# Altium schematic sheet [06]_Connectivity.SchDoc([06]_Connectivity)
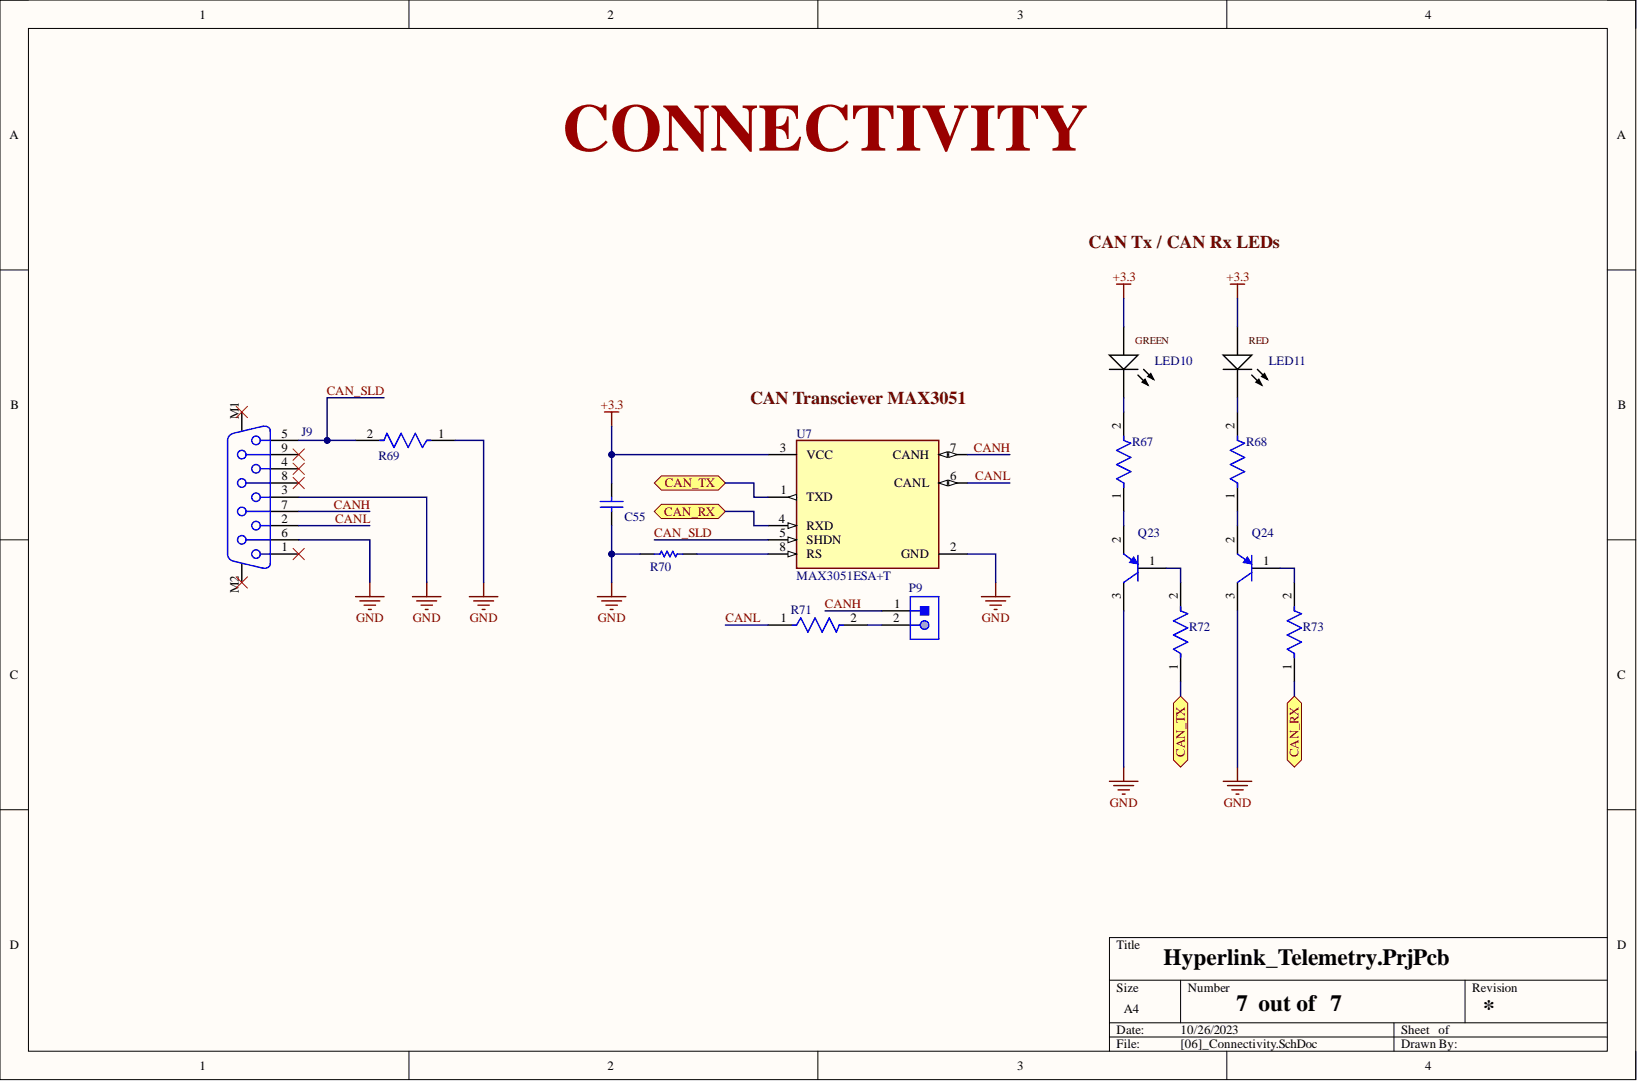
[diagram p0: [06]_Connectivity.SchDoc]

## Parts
C55  GRM188R71H104KA93D  (value not drawn)
J9  618009231121  (value not drawn)
LED10  HSMW-C191  (value not drawn)
LED11  HSMW-C191  (value not drawn)
P9  CAN_120R_JMP  (value not drawn)
Q23  BC807-25LT1G  (value not drawn)
Q24  BC807-25LT1G  (value not drawn)
R67  CRCW06031K00FKEA  (value not drawn)
R68  CRCW06031K00FKEA  (value not drawn)
R69  0603WAF1002T5E  (value not drawn)
R70  24K  (value not drawn)
R71  0603WAF1200T5E  (value not drawn)
R72  CRCW06032K20FKEAHP  (value not drawn)
R73  CRCW06032K20FKEAHP  (value not drawn)
U7  MAX3051ESA+T

## Ports
CAN_RX, CAN_TX

## Nets
+3.3: C55.2 LED10.1 LED11.1 U7.3(VCC)
GND: C55.1 J9.3 J9.6 Q23.3 Q24.3 R69.1 R70.2 U7.2(GND)
CAN_RX: R73.1 U7.4(RXD) ; port CAN_RX
CAN_SLD: J9.5 R69.2 U7.5(SHDN)
CAN_TX: R72.1 U7.1(TXD) ; port CAN_TX
CANH: J9.7 P9.1 U7.7(CANH)
CANL: J9.2 R71.1 U7.6(CANL)
NetLED10_2: LED10.2 R67.2
NetLED11_2: LED11.2 R68.2
NetP9_2: P9.2 R71.2
NetQ23_1: Q23.1 R72.2
NetQ23_2: Q23.2 R67.1
NetQ24_1: Q24.1 R73.2
NetQ24_2: Q24.2 R68.1
NetR70_1: R70.1 U7.8(RS)

Not connected: J9 1 4 8 9 M1 M2

## Library data of the parts
C55 = CMP-2100-03643-1: Chip Capacitor, 0.1 uF, +/- 10%, 50 V, -55 to 125 degC, 0603 (1608 Metric), RoHS, Tape and Reel; Manufacturer: Murata; Capacitance: 100nF; Dielectric: X7R; Dielectric Material: Ceramic; Insulation Resistance: 10GR; Voltage: 50V; Case Code (Imperial): 0603; Case Code (Metric): 1608; Case/Package: 0603; Component Kind: Standard; Contact Plating: Tin; Datasheet URL: http://psearch.en.murata.com/capacitor/product/GRM188R71H104KA93%23.pdf; Datasheet Version: 42675.0; Depth: 0.8mm; Height: 0.8mm; JLC: C14663; Lead Free: LeadFree; Length: 1.6mm; Library Name: Altium Content Vault; Manufacturer URL: http://www.murata.com/; Material: Ceramic; Max Operating Temperature: 125degC; Min Operating Temperature: -55degC; Mount: SurfaceMount; Number of Pins: 2; Package Description: 2-Pin Surface Mount Device, Body 1.6 x 0.8 mm; Package Quantity: 1; Package Reference: 0603; Packaging: TapeandReel; Radiation Hardening: No; REACH SVHC: NoSVHC; RoHSCompliant: true; Termination: SMD/SMT; Thickness: 0.035inch; Tolerance: 10%; Voltage Rating: 50V; Voltage Rating (DC): 50V; Width: 0.8mm
J9 = CMP-1502-00200-1: Female PCB Connector with Hex Screw WR-DSUB, 8.08 mm, Angled, 9 pins; Manufacturer: Wurth Elektronik; Contact Resistance: 30mOhm; Pin Number: 9; Rated Current: 3A; Working Voltage: 125VAC; Component Kind: Standard; Datasheet URL: https://katalog.we-online.de/em/datasheet/6180xx231121.pdf; Gender: Female; JLC: C75754; Library Name: Altium Content Vault; Manufacturer URL: http://www.we-online.de; Mounting Technology: THT; Operating Temperature: -40to85degC; Orientation: Angled; Package Reference: 618009231121; Packaging: Tray; Part Number: 618009231121; Publisher: WurthElektronik
LED10 = CMP-1744-00001-1: LED Uni-Color GREEN, 78 mW, 20 mA, -40 to 85 degC, 2-Pin SMD, RoHS, Tape and Reel; Current Rating: 300 mA; Component Kind: Standard; ComponentLink1Description: Manufacturer URL; ComponentLink1URL: http://www.avagotech.com/; ComponentLink2Description: Datasheet; ComponentLink2URL: http://docs.avagotech.com/docs/AV02-0186EN; Contact Finish: Silver; Contact Material: Copper; Contact Plating: Silver; DatasheetVersion: May-10; Height: 12 mm; JLC: C72043; Length: 16 mm; Library Name: Altium Content Vault; Max Operating Temperature: 85 degC; Min Operating Temperature: -20 degC; Mount: Through Hole; Package Quantity: 560; PackageDescription: 2-Pin Surface Mount Device, Body 1.6 x 0.8 mm; PackageReference: HSMW-C191; Packaging: Bulk; Radiation Hardening: No; REACH SVHC: Unknown; RoHSCompliant: true; Width: 12 mm
LED11 = CMP-1744-00001-1: LED Uni-Color RED, 78 mW, 20 mA, -40 to 85 degC, 2-Pin SMD, RoHS, Tape and Reel; Current Rating: 300 mA; Component Kind: Standard; ComponentLink1Description: Manufacturer URL; ComponentLink1URL: http://www.avagotech.com/; ComponentLink2Description: Datasheet; ComponentLink2URL: http://docs.avagotech.com/docs/AV02-0186EN; Contact Finish: Silver; Contact Material: Copper; Contact Plating: Silver; DatasheetVersion: May-10; Height: 12 mm; JLC: C72044; Length: 16 mm; Library Name: Altium Content Vault; Max Operating Temperature: 85 degC; Min Operating Temperature: -20 degC; Mount: Through Hole; Package Quantity: 560; PackageDescription: 2-Pin Surface Mount Device, Body 1.6 x 0.8 mm; PackageReference: HSMW-C191; Packaging: Bulk; Radiation Hardening: No; REACH SVHC: Unknown; RoHSCompliant: true; Width: 12 mm
P9 = CMP-1502-01059-1: THT Angled Pin Header WR-PHD, Pitch 2.54 mm, Single Row, 2 pins; Manufacturer: Wurth Elektronik; Part Number: 61300211021; Contact Resistance: 20mOhm; Gender: Male; Pin Number: 2; Pitch: 2.54mm; Rated Current: 3A; Withstanding Voltage: 500VAC; Working Voltage: 250VAC; Component Kind: Standard; Datasheet URL: https://katalog.we-online.de/em/datasheet/6130xx11021.pdf; JLC: C225488; Library Name: Altium Content Vault; Manufacturer URL: http://www.we-online.de; Mounting Technology: THT; Operating Temperature: -40to105degC; Orientation: Angled; Package Reference: 61300211021; Packaging: Bag; Row Number: Single; Publisher: WurthElektronik
Q23 Q24 = CMP-1048-00691-1: General Purpose Transistor, PNP Silicon, 3-Pin SOT-23, Pb-Free, Tape and Reel; Manufacturer: On Semiconductor; fT Min (MHz): 100; IC Continuous (A): -0.5; Component Kind: Standard; ComponentLink1Description: Manufacturer URL; ComponentLink1URL: http://www.onsemi.com/; ComponentLink2Description: Datasheet; ComponentLink2URL: http://www.onsemi.com/pub_link/Collateral/BC807-16LT1-D.PDF; ComponentLink3Description: Package Specification; ComponentLink3URL: http://www.onsemi.cn/pub_link/Collateral/318-08.PDF; DatasheetVersion: Rev. 10; JLC: C8326; Library Name: Altium Content Vault; Mounting Technology: Surface Mount; PackageDescription: 3-Pin Small Outline Transistor, Body 2.92 x 1.3 mm, Pitch 0.95 mm; PackageReference: SOT-23-3-318-08; PackageVersion: Rev. AP, 11/2009; Packing: Tape and Reel; PartNumber: BC807-25LT1G; Polarity: PNP; RoHS: TRUE
R67 R68 = CMP-1666-00003-5: RES Thick Film, 1kΩ, 1%, 0.1W, 100ppm/°C, 0603; Max Power Dissipation: 100mW; Resistance: 1kR; Voltage Rating: 75V; Case Code (Imperial): 0603; Case Code (Metric): 1608; Case/Package: 0603; Component Kind: Standard; Composition: Thick Film; Contact Plating: Tin; Depth: 0.85mm; Features: Automotive AEC-Q200; Height: 0.45mm; JLC: C21190; Lead Free: Lead Free; Length: 1.55mm; Library Name: Altium Content Vault; Max Operating Temperature: 155°C; Military Standard: Not; Min Operating Temperature: -55°C; Mount: Surface Mount; Number of Pins: 2; Number of Terminations: 2; Package Quantity: 10; Packaging: Tape and Reel; Power Rating: 100mW; Radiation Hardening: No; Temperature Coefficient: 100ppm/°C; Termination: SMD/SMT; Tolerance: 1%; Voltage Rating (DC): 75V; Weight: 7.1E-05oz; Width: 0.033inch
R69 = CMP-2000-03838-2: RES Thick Film, 10kΩ, 5%, 0.1W, 200ppm/°C, 0603; Max Power Dissipation: 100mW; Resistance: 10kR; Voltage Rating: 75V; Case Code (Imperial): 0603; Case Code (Metric): 1608; Case/Package: 0603; Component Kind: Standard; Composition: Thick Film; Contact Plating: Tin; Depth: 0.85mm; Features: Automotive AEC-Q200; Height: 0.02inch; JLC: C25804; Lead Free: Lead Free; Length: 1.55mm; Library Name: Altium Content Vault; Max Operating Temperature: 155°C; Military Standard: Not; Min Operating Temperature: -55°C; Mount: Surface Mount; Number of Pins: 2; Number of Terminations: 2; Package Quantity: 1; Packaging: Tape and Reel; Power Rating: 100mW; Radiation Hardening: No; Temperature Coefficient: 200ppm/°C; Termination: SMD/SMT; Tolerance: 5%; Voltage Rating (DC): 75V; Weight: 7.1E-05oz; Width: 0.033inch
R70 = CMP-2001-00550-5: Chip Resistor, 4.7 KOhm, +/- 1%, 0.125 W, -55 to 155 degC, 0805 (2012 Metric), RoHS, Tape and Reel; Manufacturer: Panasonic; Voltage Rating: 150 V; Component Kind: Standard; ComponentLink1Description: Manufacturer URL; ComponentLink1URL: http://www.panasonic.com/; ComponentLink2Description: Datasheet; ComponentLink2URL: http://industrial.panasonic.com/cdbs/www-data/pdf/RDA0000/AOA0000C86.pdf; DatasheetVersion: 04/2015; Height: 0.6 mm; JLC: C23352; Length: 2 mm; Library Name: Altium Content Vault; Max Operating Temperature: 155 degC; Min Operating Temperature: -55 degC; Mount: Surface Mount; Mounting Technology: Surface Mount; Package Quantity: 5000; PackageDescription: 2-Pin Surface Mount Device, Body 2 x 1.25 mm; PackageReference: 0805; Packaging: Tape and Reel; Pins: 2; REACH SVHC: Yes; RoHSCompliant: true
R71 = CMP-1012-00052-3: RES Thick Film, 120Ω, 5%, 0.1W, 200ppm/°C, 0603; Max Power Dissipation: 100mW; Resistance: 120R; Voltage Rating: 75V; Case Code (Imperial): 0603; Case Code (Metric): 1608; Case/Package: 0603; Component Kind: Standard; Composition: Thick Film; Contact Plating: Tin; Depth: 0.85mm; Features: Automotive AEC-Q200; Height: 0.02inch; JLC: C22787; Lead Free: Lead Free; Length: 1.55mm; Library Name: Altium Content Vault; Max Operating Temperature: 155°C; Military Standard: Not; Min Operating Temperature: -55°C; Number of Pins: 2; Number of Terminations: 2; Package Quantity: 1; Packaging: Tape and Reel; Power Rating: 100mW; Radiation Hardening: No; Temperature Coefficient: 200ppm/°C; Termination: SMD/SMT; Tolerance: 5%; Voltage Rating (DC): 75V; Weight: 7.1E-05oz; Width: 0.85mm
R72 R73 = CMP-02407-010809-1: RES Thick Film, 2.2kΩ, 1%, 0.33W, 100ppm/°C, 0603; Max Power Dissipation: 250mW; Resistance: 2.2kR; Voltage Rating: 75V; Case Code (Imperial): 0603; Case Code (Metric): 1608; Case/Package: 0603; Component Kind: Standard; Composition: Thick Film; Contact Plating: Tin; Depth: 0.85mm; Features: Automotive AEC-Q200; Height: 0.02inch; JLC: C4190; Lead Free: Lead Free; Length: 1.6mm; Library Name: Altium Content Vault; Max Operating Temperature: 155°C; Military Standard: Not; Min Operating Temperature: -55°C; Mount: Surface Mount; Number of Terminations: 2; Package Quantity: 1; Packaging: Tape and Reel; Power Rating: 250mW; Radiation Hardening: No; Temperature Coefficient: 100ppm/°C; Termination: Solder; Tolerance: 1%; Voltage Rating (DC): 75V; Width: 0.033inch
U7 = CMP-05676-000007-1: IC TRANSCEIVER HALF 1/1 8SO; Data Rate: 1Mbps; Interface: CAN; Max Supply Voltage: 3.465V; Min Supply Voltage: 3.135V; Number of Receive Buffers: 1; Operating Supply Current: 35mA; Operating Supply Voltage: 3.3V; Receiver Hysteresis: 20mV; Simplex/Duplex: Full Duplex; Case/Package: SO; Component Kind: Standard; JLC: C15648; Lead Free: Lead Free; Library Name: Altium Content Vault; Max Operating Temperature: 85°C; Min Operating Temperature: -40°C; Mount: Surface Mount; Number of Pins: 8; Number of Transceivers: 1; Number of Transmit Buffers: 1; Package Quantity: 2500; Packaging: Tape and Reel; Radiation Hardening: No; Weight: 0.01787oz
